# Altium schematic sheet Salmon.SchDoc(Salmon)
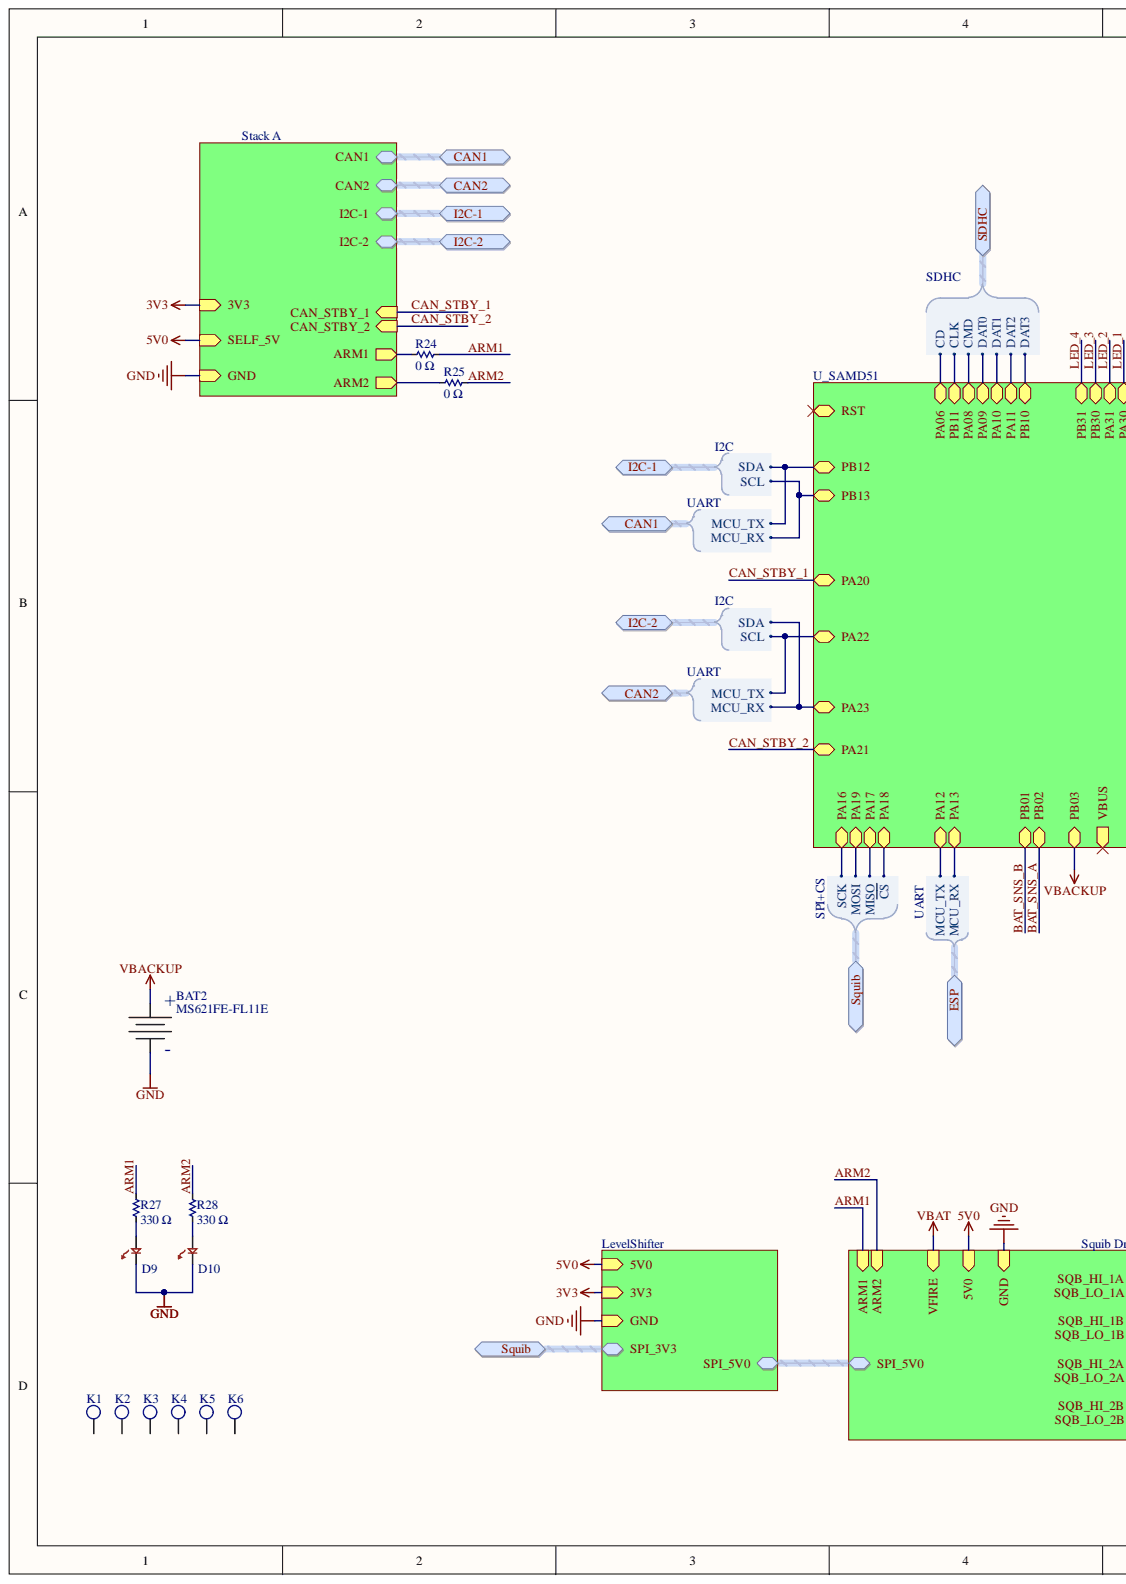
[diagram p0: Salmon.SchDoc, left]
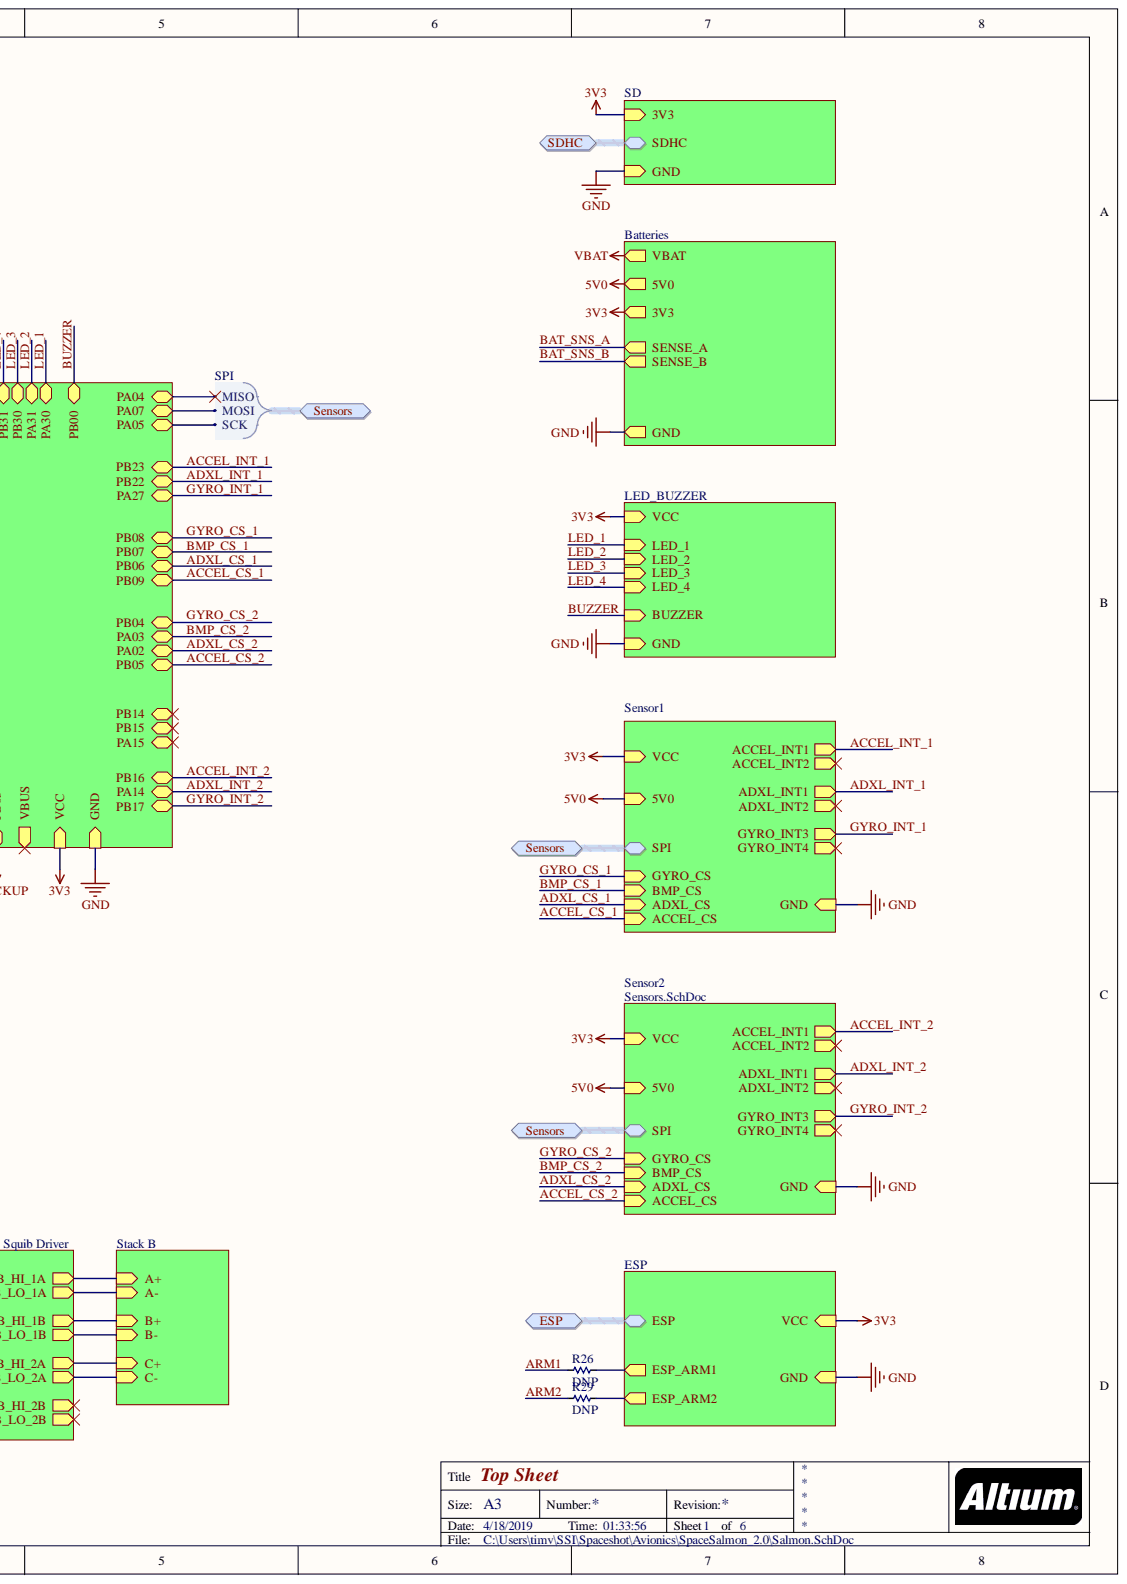
[diagram p1: Salmon.SchDoc, right]

## Parts
BAT2  MS621FE-FL11E  [BATT_COINCELL_RECHARGEABLE]
D9  RED  [0805_Diode]  (value not drawn)
D10  RED  [0805_Diode]  (value not drawn)
K1  92985A610  [#4_PRESSFIT_FOOT_1/4]  (value not drawn)
K2  92985A610  [#4_PRESSFIT_FOOT_1/4]  (value not drawn)
K3  92985A610  [#4_PRESSFIT_FOOT_1/4]  (value not drawn)
K4  92985A610  [#4_PRESSFIT_FOOT_1/4]  (value not drawn)
K5  92985A610  [#4_PRESSFIT_FOOT_1/4]  (value not drawn)
K6  92985A610  [#4_PRESSFIT_FOOT_1/4]  (value not drawn)
R24  Resistance: 0 Ω  [R0603]  (hidden comment: __template_resistor)
R25  Resistance: 0 Ω  [R0603]  (hidden comment: __template_resistor)
R26  Resistance (Sortable): DNP  [R0603]  (hidden comment: __template_resistor)
R27  Resistance: 330 Ω  [R0603]  (hidden comment: __template_resistor)
R28  Resistance: 330 Ω  [R0603]  (hidden comment: __template_resistor)
R29  Resistance (Sortable): DNP  [R0603]  (hidden comment: __template_resistor)

## Ports
CAN1, CAN1.MCU_RX, CAN1.MCU_TX, CAN2, CAN2.MCU_RX, CAN2.MCU_TX, ESP, ESP.MCU_RX, ESP.MCU_TX, I2C-1, I2C-1.SCL, I2C-1.SDA, I2C-2, I2C-2.SCL, I2C-2.SDA, SDHC, SDHC.CD, SDHC.CLK, SDHC.CMD, SDHC.DAT0, SDHC.DAT1, SDHC.DAT2, SDHC.DAT3, Sensors, Sensors.MISO, Sensors.MOSI, Sensors.SCK, Squib, Squib.MISO, Squib.MOSI, Squib.SCK, Squib.~{CS}

## Nets
GND: BAT2.- D9.1 D10.1
VBACKUP: BAT2.+
ARM1: R24.2 R26.1 R27.2
ARM2: R25.2 R28.2 R29.1
NetD9_2: D9.2 R27.1
NetD10_2: D10.2 R28.1
NetK1_1: K1.1
NetK2_1: K2.1
NetK3_1: K3.1
NetK4_1: K4.1
NetK5_1: K5.1
NetK6_1: K6.1
NetR24_1: R24.1
NetR25_1: R25.1
NetR26_2: R26.2
NetR29_2: R29.2
3V3:
5V0:
ACCEL_CS_1:
ACCEL_CS_2:
ACCEL_INT2:
ACCEL_INT_1:
ACCEL_INT_2:
ADXL_CS_1:
ADXL_CS_2:
ADXL_INT2:
ADXL_INT_1:
ADXL_INT_2:
BAT_SNS_A:
BAT_SNS_B:
BMP_CS_1:
BMP_CS_2:
BUZZER:
CAN1.MCU_RX: ; port CAN1, port I2C-1
CAN1.MCU_TX: ; port CAN1, port I2C-1
CAN2.MCU_RX: ; port CAN2, port I2C-2
CAN2.MCU_TX: ; port CAN2, port I2C-2
CAN_STBY_1:
CAN_STBY_2:
ESP.MCU_RX: ; port ESP
ESP.MCU_TX: ; port ESP
GYRO_CS_1:
GYRO_CS_2:
GYRO_INT4:
GYRO_INT_1:
GYRO_INT_2:
LED_1:
LED_2:
LED_3:
LED_4:
PA15:
PB14:
PB15:
RST:
SDHC.CD: ; port SDHC
SDHC.CLK: ; port SDHC
SDHC.CMD: ; port SDHC
SDHC.DAT0: ; port SDHC
SDHC.DAT1: ; port SDHC
SDHC.DAT2: ; port SDHC
SDHC.DAT3: ; port SDHC
SPI.MISO: ; port Sensors
SPI.MOSI: ; port Sensors
SPI.SCK: ; port Sensors
SPI_3V3.~{CS}: ; port Squib
SPI_3V3.MISO: ; port Squib
SPI_3V3.MOSI: ; port Squib
SPI_3V3.SCK: ; port Squib
SPI_5V0.~{CS}:
SPI_5V0.MISO:
SPI_5V0.MOSI:
SPI_5V0.SCK:
SQB_HI_1A:
SQB_HI_1B:
SQB_HI_2A:
SQB_HI_2B:
SQB_LO_1A:
SQB_LO_1B:
SQB_LO_2A:
SQB_LO_2B:
VBAT:
VBUS:

## Library data of the parts
BAT2 = BAT_MS621FE: MS621FE RECHARGEABLE COIN CELL BACKUP; Manufacturer: Seiko Instruments; Standard Charge Current: 15µA; Shipping Info: Due to restrictions, only ground shipment is allowed.; Capacity: 5.5mAh; Battery Chemistry: Lithium; Battery Cell Size: Coin, 6.8mm; Weight: 0.23g; Termination Style: SMD (SMT) Tab; Supplier Part Number 1: 728-1057-ND; Supplier 1: Digi-Key; Stock 1: 41059; Standard Charge Time: -; Size / Dimension: 0.27" Dia x 0.08" H (6.8mm x 2.1mm); RoHS: RoHS Compliant; Pricing 1: 1=2.04, 10=1.838, 50=1.6338, 100=1.4296, 250=1.30704, 500=1.22534, 1000=1.09051, 2500=1.0112, 5000=0.99138 (USD); Part Status: Active; Manufacturer Part Number: MS621FE-FL11E; Discharge Rate: 15µA; ComponentLink1URL: http://www.sii.co.jp/en/me/files/2017/04/MicroBatteryCatalogue-E_2017_web.pdf; ComponentLink1Description: http://www.sii.co.jp/en/me/files/2017/04/MicroBatteryCatalogue-E_2017_web.pdf; Component Kind: Standard; Category: Battery Products; Library Name: SSI.IntLib
D9 D10 = LED_LY974: LED 0805 RED 20MA; Manufacturer Part Number: LH R974-LP-1; Manufacturer: OSRAM Opto Semiconductors Inc; Wavelength - Peak: 660nm; Wavelength - Dominant: 645nm; Voltage - Forward (Vf) (Typ): 1.8V; Viewing Angle: 160°; Millicandela Rating: 41mcd; Lens Transparency: Diffused; Lens Style/Size: Rectangle with Flat Top, 1.30mm x 1.25mm; Lens Color: White; Supplier Part Number 1: 475-1415-1-ND; Supplier 1: Digi-Key; Stock 1: 66379; Size / Dimension: 2.00mm L x 1.25mm W; RoHS: RoHS Compliant; Pricing 1: 1=0.08, 10=0.07, 100=0.0559, 250=0.04892, 500=0.04472 (USD); Packaging: Cut Tape (CT); Package / Case: 0805 (2012 Metric); Mounting Type: Surface Mount; Height (Max): 0.80mm; Current - Test: 20mA; ComponentLink1URL: http://www.osram-os.com/Graphics/XPic8/00078869_0.pdf; ComponentLink1Description: http://www.osram-os.com/Graphics/XPic8/00078869_0.pdf; Component Kind: Standard; Color: Red; Category: Optoelectronics; Library Name: SSI.IntLib
K1-K6 = #4_PRESSFIT_MOUNTING_FOOT: Press-Fit Unthreaded Spacers, 7/32" OD, 1/8" Long; Supplier Link: https://www.mcmaster.com/#92985a610/=16cb2ec; Library Name: mechanical.SchLib; Component Kind: Standard
R24 R25 = RES SMD 0 OHM JUMPER 1/10W 0603: Manufacturer Part Number: RC0603FR-070RL; Manufacturer: Yageo; Tolerance: Jumper; Resistance (Sortable): 0; Power (Watts): 0.1W, 1/10W; Database Table Name: R0603$; Temperature Coefficient: -; Supplier Part Number 1: 311-0.0HRCT-ND; Supplier 1: Digikey; Operating Temperature: -55°C ~ 155°C; Library Name: passives.DbLib; Image: //media.digikey.com/Renders/Yageo%20Renders/0603-(1608-Metric).jpg; Datasheets: http://www.yageo.com/documents/recent/PYu-RC_Group_51_RoHS_L_9.pdf; Component Kind: Standard
R26 R29 = RES SMD DNP 0603: Database Table Name: R0603$; Resistance: DNP; Library Name: passives.DbLib; Component Kind: Standard
R27 R28 = RES SMD 330 OHM 1% 1/10W 0603: Manufacturer Part Number: RC0603FR-07330RL; Manufacturer: Yageo; Tolerance: ±1%; Temperature Coefficient: ±100ppm/°C; Resistance (Sortable): 330; Power (Watts): 0.1W, 1/10W; Database Table Name: R0603$; Supplier Part Number 1: 311-330HRCT-ND; Supplier 1: Digikey; Operating Temperature: -55°C ~ 155°C; Library Name: passives.DbLib; Image: //media.digikey.com/Renders/Yageo%20Renders/0603-(1608-Metric).jpg; Datasheets: http://www.yageo.com/documents/recent/PYu-RC_Group_51_RoHS_L_9.pdf; Component Kind: Standard
